# Altium schematic sheet 03-KSZ9567.SchDoc(03-KSZ9567)
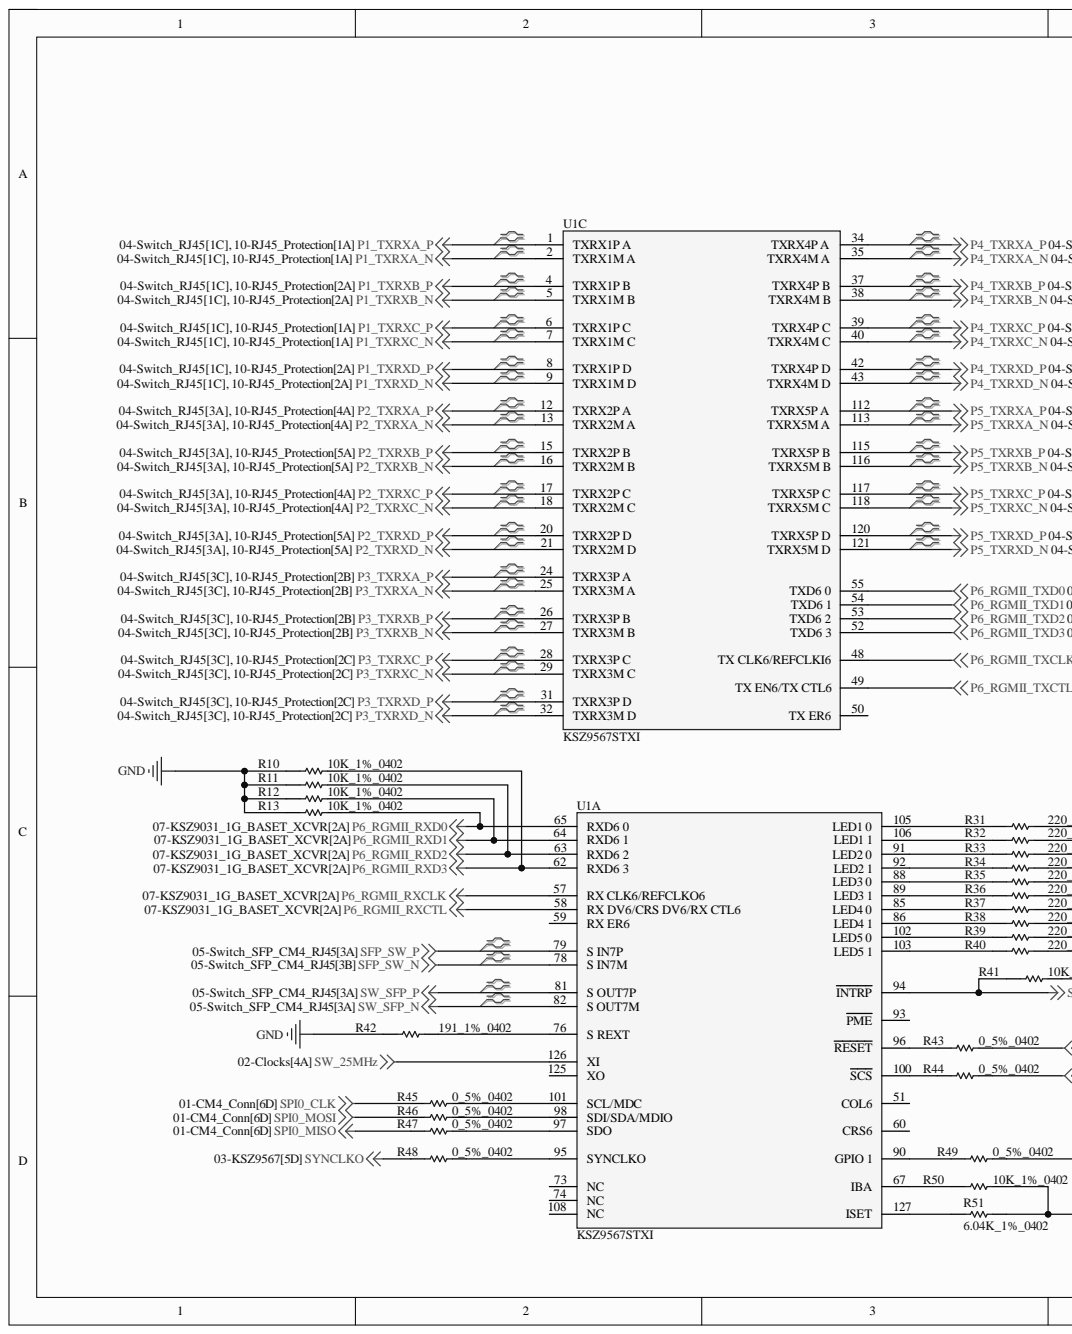
[diagram p0: 03-KSZ9567.SchDoc, left]
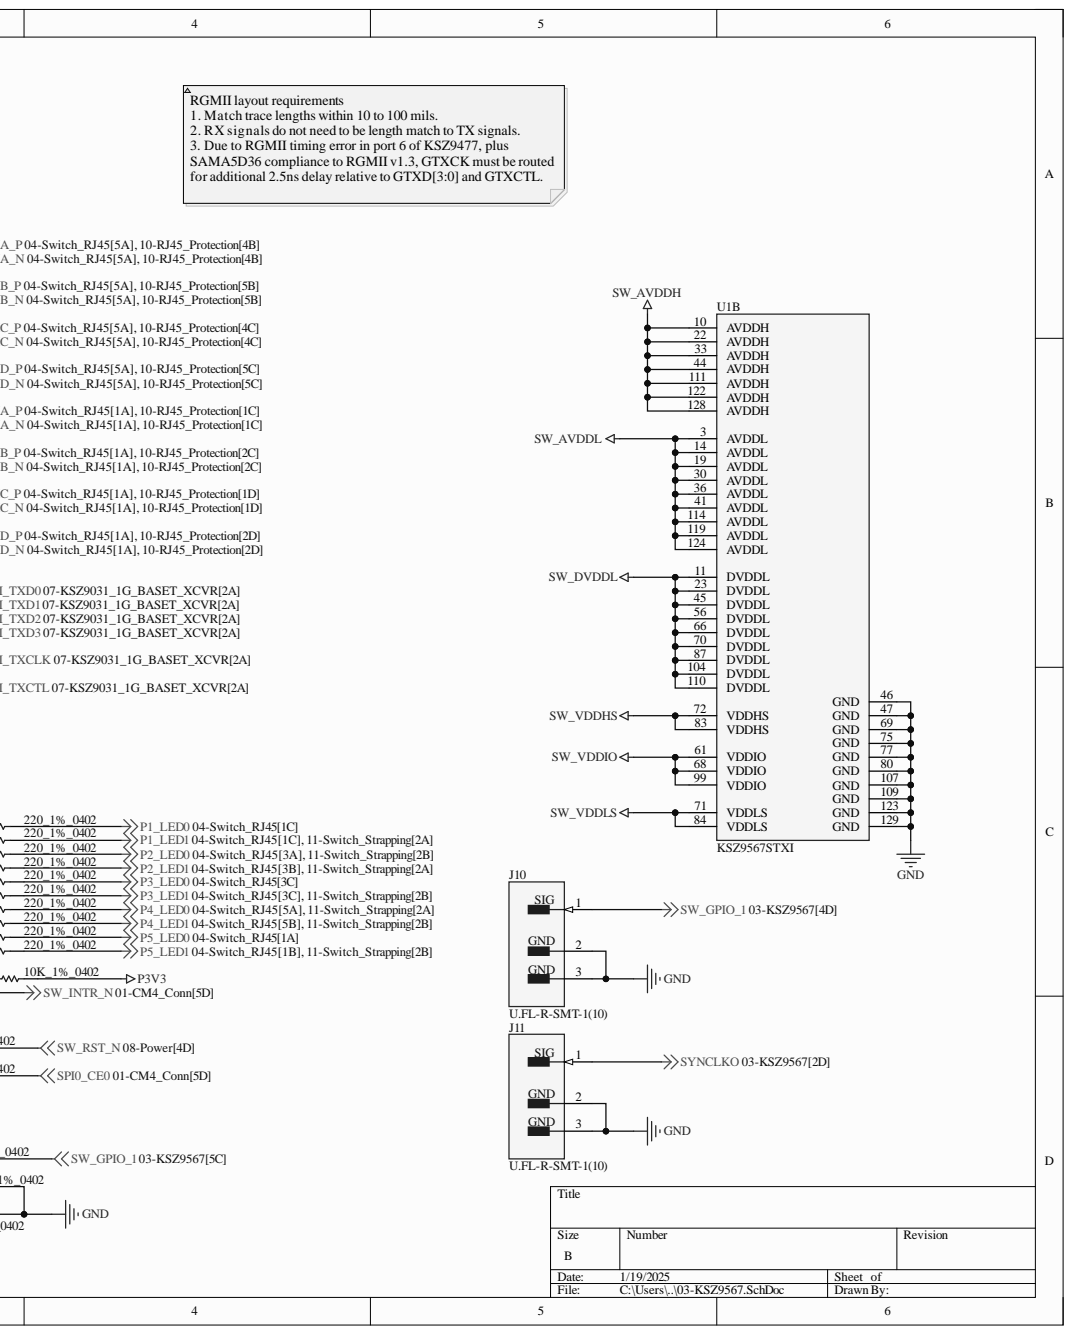
[diagram p1: 03-KSZ9567.SchDoc, right]

## Parts
J10  U.FL-R-SMT-1(10)  [FP-U_FL-R-SMT-1_10-MFG]
J11  U.FL-R-SMT-1(10)  [FP-U_FL-R-SMT-1_10-MFG]
R10  10K_1%_0402  [RESC1005X40X25NL10T10]
R11  10K_1%_0402  [RESC1005X40X25NL10T10]
R12  10K_1%_0402  [RESC1005X40X25NL10T10]
R13  10K_1%_0402  [RESC1005X40X25NL10T10]
R31  220_1%_0402  [RESC1005X40X25NL05T10]
R32  220_1%_0402  [RESC1005X40X25NL05T10]
R33  220_1%_0402  [RESC1005X40X25NL05T10]
R34  220_1%_0402  [RESC1005X40X25NL05T10]
R35  220_1%_0402  [RESC1005X40X25NL05T10]
R36  220_1%_0402  [RESC1005X40X25NL05T10]
R37  220_1%_0402  [RESC1005X40X25NL05T10]
R38  220_1%_0402  [RESC1005X40X25NL05T10]
R39  220_1%_0402  [RESC1005X40X25NL05T10]
R40  220_1%_0402  [RESC1005X40X25NL05T10]
R41  10K_1%_0402  [RESC1005X40X25NL10T10]
R42  191_1%_0402  [RESC1005X40X25LL10T10]
R43  0_5%_0402  [RESC1005X40X25NL05T10]
R44  0_5%_0402  [RESC1005X40X25NL05T10]
R45  0_5%_0402  [RESC1005X40X25NL05T10]
R46  0_5%_0402  [RESC1005X40X25NL05T10]
R47  0_5%_0402  [RESC1005X40X25NL05T10]
R48  0_5%_0402  [RESC1005X40X25NL05T10]
R49  0_5%_0402  [RESC1005X40X25NL05T10]
R50  10K_1%_0402  [RESC1005X40X25NL10T10]
R51  6.04K_1%_0402  [RESC1005X40X25NL10T10]
U1  KSZ9567STXI  [FP-C04-418-IPC_A]  (parts A,B,C)

## Nets
GND: J10.2 J10.3 J11.2 J11.3 R10.1 R11.1 R12.1 R13.1 R42.1 R50.2 R51.2 U1.46(GND) U1.47(GND) U1.69(GND) U1.75(GND) U1.77(GND) U1.80(GND) U1.107(GND) U1.109(GND) U1.123(GND) U1.129(GND)
P1_LED0: R31.2
P1_LED1: R32.2
P1_TXRXA_N: U1.2(TXRX1M_A)
P1_TXRXA_P: U1.1(TXRX1P_A)
P1_TXRXB_N: U1.5(TXRX1M_B)
P1_TXRXB_P: U1.4(TXRX1P_B)
P1_TXRXC_N: U1.7(TXRX1M_C)
P1_TXRXC_P: U1.6(TXRX1P_C)
P1_TXRXD_N: U1.9(TXRX1M_D)
P1_TXRXD_P: U1.8(TXRX1P_D)
P2_LED0: R33.2
P2_LED1: R34.2
P2_TXRXA_N: U1.13(TXRX2M_A)
P2_TXRXA_P: U1.12(TXRX2P_A)
P2_TXRXB_N: U1.16(TXRX2M_B)
P2_TXRXB_P: U1.15(TXRX2P_B)
P2_TXRXC_N: U1.18(TXRX2M_C)
P2_TXRXC_P: U1.17(TXRX2P_C)
P2_TXRXD_N: U1.21(TXRX2M_D)
P2_TXRXD_P: U1.20(TXRX2P_D)
P3_LED0: R35.2
P3_LED1: R36.2
P3_TXRXA_N: U1.25(TXRX3M_A)
P3_TXRXA_P: U1.24(TXRX3P_A)
P3_TXRXB_N: U1.27(TXRX3M_B)
P3_TXRXB_P: U1.26(TXRX3P_B)
P3_TXRXC_N: U1.29(TXRX3M_C)
P3_TXRXC_P: U1.28(TXRX3P_C)
P3_TXRXD_N: U1.32(TXRX3M_D)
P3_TXRXD_P: U1.31(TXRX3P_D)
P3V3: R41.2
P4_LED0: R37.2
P4_LED1: R38.2
P4_TXRXA_N: U1.35(TXRX4M_A)
P4_TXRXA_P: U1.34(TXRX4P_A)
P4_TXRXB_N: U1.38(TXRX4M_B)
P4_TXRXB_P: U1.37(TXRX4P_B)
P4_TXRXC_N: U1.40(TXRX4M_C)
P4_TXRXC_P: U1.39(TXRX4P_C)
P4_TXRXD_N: U1.43(TXRX4M_D)
P4_TXRXD_P: U1.42(TXRX4P_D)
P5_LED0: R39.2
P5_LED1: R40.2
P5_TXRXA_N: U1.113(TXRX5M_A)
P5_TXRXA_P: U1.112(TXRX5P_A)
P5_TXRXB_N: U1.116(TXRX5M_B)
P5_TXRXB_P: U1.115(TXRX5P_B)
P5_TXRXC_N: U1.118(TXRX5M_C)
P5_TXRXC_P: U1.117(TXRX5P_C)
P5_TXRXD_N: U1.121(TXRX5M_D)
P5_TXRXD_P: U1.120(TXRX5P_D)
P6_RGMII_RXCLK: U1.57(RX_CLK6/REFCLKO6)
P6_RGMII_RXCTL: U1.58(RX_DV6/CRS_DV6/RX_CTL6)
P6_RGMII_RXD0: R13.2 U1.65(RXD6_0)
P6_RGMII_RXD1: R12.2 U1.64(RXD6_1)
P6_RGMII_RXD2: R11.2 U1.63(RXD6_2)
P6_RGMII_RXD3: R10.2 U1.62(RXD6_3)
P6_RGMII_TXCLK: U1.48(TX_CLK6/REFCLKI6)
P6_RGMII_TXCTL: U1.49(TX_EN6/TX_CTL6)
P6_RGMII_TXD0: U1.55(TXD6_0)
P6_RGMII_TXD1: U1.54(TXD6_1)
P6_RGMII_TXD2: U1.53(TXD6_2)
P6_RGMII_TXD3: U1.52(TXD6_3)
SFP_SW_N: U1.78(S_IN7M)
SFP_SW_P: U1.79(S_IN7P)
SPI0_CE0: R44.2
SPI0_CLK: R45.1
SPI0_MISO: R47.1
SPI0_MOSI: R46.1
SW_25MHz: U1.126(XI)
SW_AVDDH: U1.10(AVDDH) U1.22(AVDDH) U1.33(AVDDH) U1.44(AVDDH) U1.111(AVDDH) U1.122(AVDDH) U1.128(AVDDH)
SW_AVDDL: U1.3(AVDDL) U1.14(AVDDL) U1.19(AVDDL) U1.30(AVDDL) U1.36(AVDDL) U1.41(AVDDL) U1.114(AVDDL) U1.119(AVDDL) U1.124(AVDDL)
SW_DVDDL: U1.11(DVDDL) U1.23(DVDDL) U1.45(DVDDL) U1.56(DVDDL) U1.66(DVDDL) U1.70(DVDDL) U1.87(DVDDL) U1.104(DVDDL) U1.110(DVDDL)
SW_GPIO_1: J10.1 R49.2
SW_INTR_N: R41.1 U1.94(INTRP)
SW_RST_N: R43.2
SW_SFP_N: U1.82(S_OUT7M)
SW_SFP_P: U1.81(S_OUT7P)
SW_VDDHS: U1.72(VDDHS) U1.83(VDDHS)
SW_VDDIO: U1.61(VDDIO) U1.68(VDDIO) U1.99(VDDIO)
SW_VDDLS: U1.71(VDDLS) U1.84(VDDLS)
SYNCLKO: J11.1 R48.1
NetR31_1: R31.1 U1.105(LED1_0)
NetR32_1: R32.1 U1.106(LED1_1)
NetR33_1: R33.1 U1.91(LED2_0)
NetR34_1: R34.1 U1.92(LED2_1)
NetR35_1: R35.1 U1.88(LED3_0)
NetR36_1: R36.1 U1.89(LED3_1)
NetR37_1: R37.1 U1.85(LED4_0)
NetR38_1: R38.1 U1.86(LED4_1)
NetR39_1: R39.1 U1.102(LED5_0)
NetR40_1: R40.1 U1.103(LED5_1)
NetR42_2: R42.2 U1.76(S_REXT)
NetR43_1: R43.1 U1.96(RESET)
NetR44_1: R44.1 U1.100(SCS)
NetR45_2: R45.2 U1.101(SCL/MDC)
NetR46_2: R46.2 U1.98(SDI/SDA/MDIO)
NetR47_2: R47.2 U1.97(SDO)
NetR48_2: R48.2 U1.95(SYNCLKO)
NetR49_1: R49.1 U1.90(GPIO_1)
NetR50_1: R50.1 U1.67(IBA)
NetR51_1: R51.1 U1.127(ISET)

Not connected: U1 50(TX_ER6) 51(COL6) 59(RX_ER6) 60(CRS6) 73(NC) 74(NC) 93(PME) 108(NC) 125(XO)

## Library data of the parts
J10 J11 = CMP-2000-05152-3: CONN U.FL RCPT STR 50 OHM SMD; Body Material: Bronze; Body Plating: Silver; Connector Type: Coaxial; Dielectric Material: Polymer; Frequency: 6GHz; Gender: Male; Impedance: 50R; Insulation Resistance: 500MR; Component Kind: Standard; Contact Material: Brass; Contact Plating: Gold; Depth: 3.1mm; Fastening Type: Snap; Housing Color: Beige; Lead Free: Lead Free; Length: 3mm; Library Name: Altium Content Vault; Max Frequency: 6GHz; Max Operating Temperature: 90°C; Min Operating Temperature: -40°C; Mount: Surface Mount; Orientation: Straight; Package Quantity: 2500; Packaging: Cut Tape; Radiation Hardening: No; REACH SVHC: No SVHC; Series: U.FL; Termination: Solder; Weight: 0.001764oz; Wire/Cable Type: Coaxial
R10-R13 R41 R50 = CMP-2002-04694-1: Manufacturer: Bourns; Part Number: CR0402-FX-1002GLF; Component Kind: Standard; Library Name: Altium Content Vault
R31-R40 = CMP-2002-07959-1: Manufacturer: Yageo / Phycomp; Part Number: RC0402JR-07220RL; Component Kind: Standard; Library Name: Altium Content Vault
R42 = CMP-2002-04891-1: Manufacturer: Bourns; Part Number: CR0402-FX-1910GLF; Component Kind: Standard; Library Name: Altium Content Vault
R43-R49 = CMP-2002-06457-1: Manufacturer: Panasonic; Part Number: ERJ-U020R00X; Component Kind: Standard; Library Name: Altium Content Vault
R51 = CMP-2002-06319-1: Manufacturer: Multicomp; Part Number: MC00625W040216K04; Component Kind: Standard; Library Name: Altium Content Vault
U1 = CMP-06281-000207-1: IC ETHERNET SWITCH 7PORT 128TQFP; Interface: I2C; Component Kind: Standard; Library Name: Altium Content Vault; Max Operating Temperature: 85°C; Min Operating Temperature: -40°C; Packaging: Tray; RoHS Compliant: Yes
